# Altium schematic sheet test.SchDoc
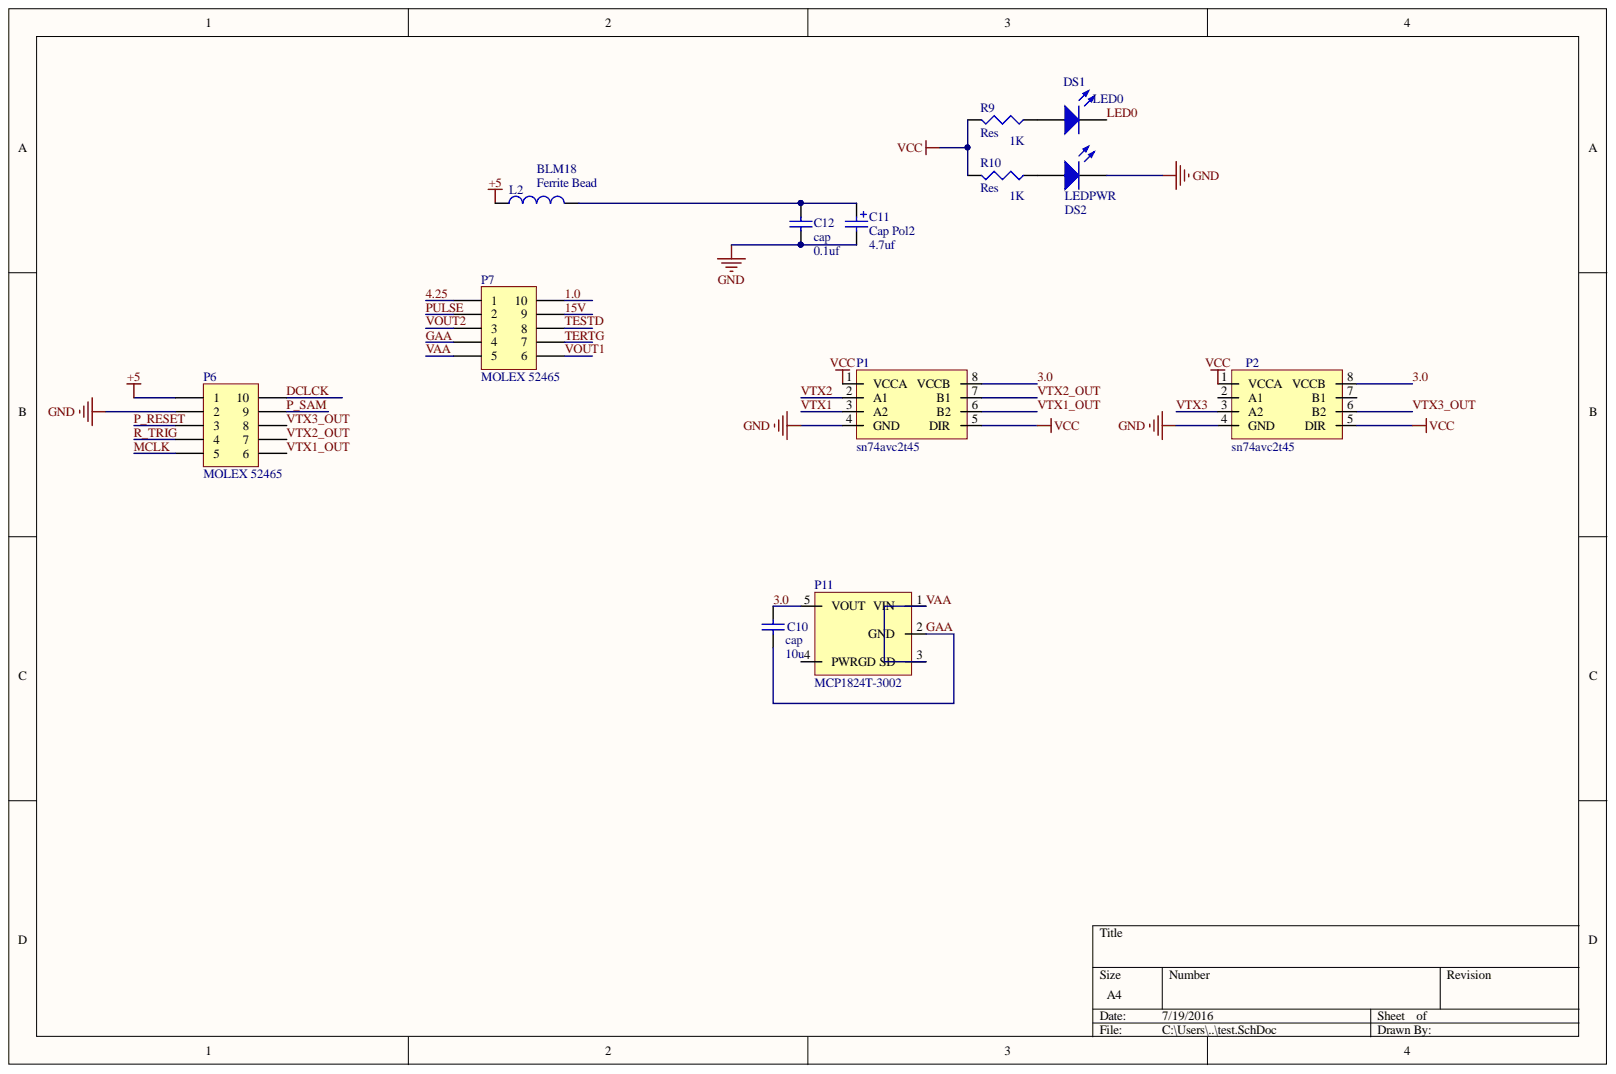
[diagram p0: test.SchDoc]

## Parts
C10  cap  value 10u  [J1-0603]
C11  Cap Pol2  value 4.7uf  [C1206+]
C12  cap  value 0.1uf  [J1-0603]
DS1  LED0  [SMDLED]
DS2  LEDPWR  [SMDLED]
L2  Ferrite Bead  value BLM18  [J1-0603]
P1  sn74avc2t45  [TSSOP8]
P2  sn74avc2t45  [TSSOP8]
P6  MOLEX 52465  [btb10p_m]
P7  MOLEX 52465  [btb10p_m]
P11  MCP1824T-3002  [SOT-23]
R9  Res  value 1K  [J1-0603]
R10  Res  value 1K  [J1-0603]

## Nets
+5: L2.1 P6.1
GND: C11.2 C12.1 DS2.2 P1.4(GND) P2.4(GND) P6.2
VCC: P1.1(VCCA) P1.5(DIR) P2.1(VCCA) P2.5(DIR) R9.2 R10.2
1.0: P7.10
3.0: C10.2 P1.8(VCCB) P2.8(VCCB) P11.5(VOUT)
4.25: P7.1
15V: P7.9
DCLCK: P6.10
GAA: C10.1 P7.4 P11.2(GND)
LED0: DS1.2
MCLK: P6.5
P_RESET: P6.3
P_SAM: P6.9
PULSE: P7.2
R_TRIG: P6.4
TERTG: P7.7
TESTD: P7.8
VAA: P7.5 P11.1(VIN) P11.3(SD)
VOUT1: P7.6
VOUT2: P7.3
VTX1: P1.3(A2)
VTX1_OUT: P1.6(B2) P6.6
VTX2: P1.2(A1)
VTX2_OUT: P1.7(B1) P6.7
VTX3: P2.3(A2)
VTX3_OUT: P2.6(B2) P6.8
NetC11_1: C11.1 C12.2 L2.2
NetDS1_1: DS1.1 R9.1
NetDS2_1: DS2.1 R10.1

Not connected: P2 2(A1) 7(B1); P11 4(PWRGD)

## Library data of the parts
C10 C12 = Cap Semi: Capacitor (Semiconductor SIM Model); Component Kind: Standard; LatestRevisionDate: 29-May-2009; LatestRevisionNote: IPC-7351 Footprint Added.; Library Name: Miscellaneous Devices.IntLib; PackageDescription: Chip Capacitor; PackageReference: C1206; Published: 8-Jun-2000; Signal Integrity: Cap Semi; Simulation: CAP; Publisher: Altium Limited
C11 = Cap Pol2: Polarized Capacitor (Axial); Component Kind: Standard; LatestRevisionDate: 17-Jul-2002; LatestRevisionNote: Re-released for DXP Platform.; Library Name: Miscellaneous Devices.IntLib; PackageDescription: Polarized Capacitor; 2 Leads; PackageReference: POLAR0.8; Published: 8-Jun-2000; Signal Integrity: Cap Pol; Simulation: CAP; Publisher: Altium Limited
DS1 DS2 = LED2: Typical RED, GREEN, YELLOW, AMBER GaAs LED; Component Kind: Standard; LatestRevisionDate: 17-Jul-2002; LatestRevisionNote: Re-released for DXP Platform.; PackageDocument: Feb-2002; PackageReference: 3.2X1.6X1.1; Published: 8-Jun-2000; Simulation: LED2; Library Name: 2014ﾄ鷭STM32F4 Exploerｿｪｷ｢ｰ・V1.4_ｽﾌｳﾌﾂﾒｸﾄｰ觸EXPLORER.SCHLIB; Publisher: Altium Limited
L2 = Inductor: Code_IEC: 1005; Code_JEITA: 0402; Component Kind: Standard; Description: Inductor; LatestRevisionDate: 29-May-2009; LatestRevisionNote: IPC-7351 Footprint Added.; Library Name: Miscellaneous Devices.IntLib; PackageDescription: Chip Inductor; PackageReference: 0402-A; Published: 8-Jun-2000; Signal Integrity: Inductor; Simulation: INDUCTOR; Publisher: Altium Limited
P6 P7 = header10: Component Kind: Standard; Library Name: jskschemlib.SCHLIB
P11 = VOLTREG: Component Kind: Standard; Library Name: jskschemlib.SCHLIB
R9 R10 = Res3: Resistor; Component Kind: Standard; LatestRevisionDate: 29-May-2009; LatestRevisionNote: IPC-7351 Footprint Added.; Library Name: Miscellaneous Devices.IntLib; PackageDescription: Chip Resistor; PackageReference: J1-0603; Published: 8-Jun-2000; Signal Integrity: Res; Simulation: RESISTOR; Publisher: Altium Limited
P1 P2 = sn74avc2t45: Component Kind: Standard; Library Name: jskschemlib.SCHLIB
